# Altium schematic sheet 2-1 CPU.SchDoc("2-1 CPU")
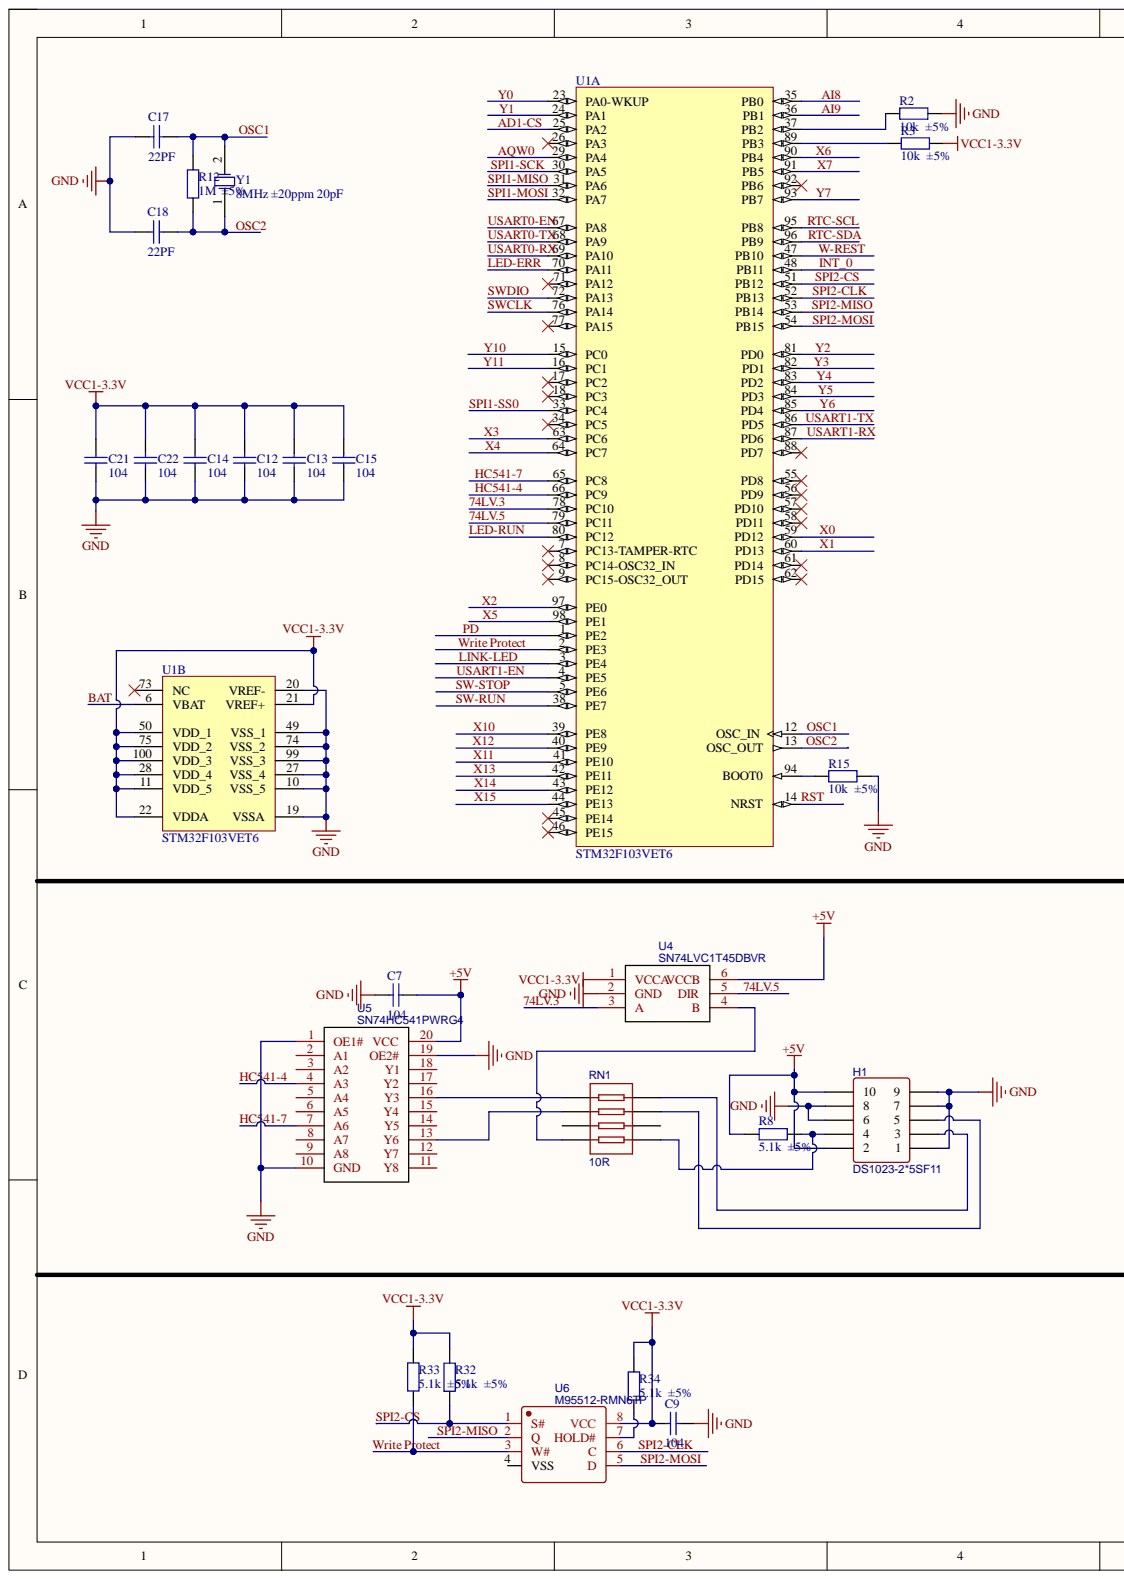
[diagram p0: 2-1 CPU.SchDoc, left]
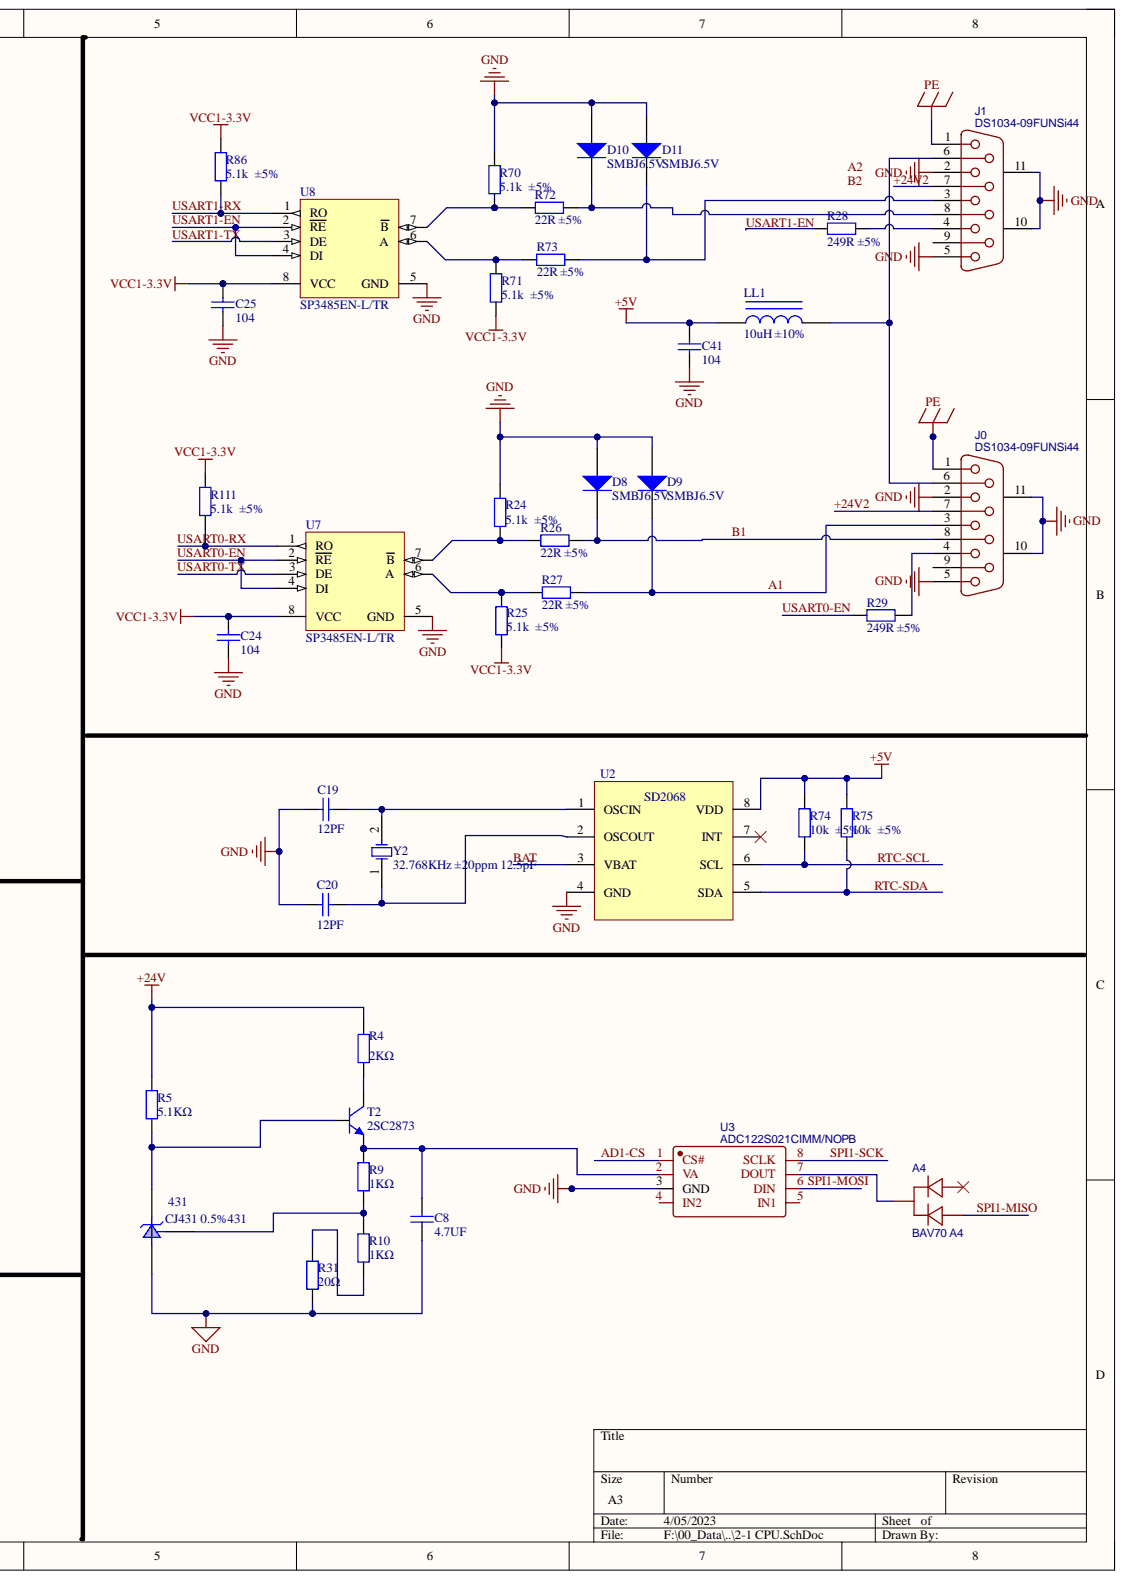
[diagram p1: 2-1 CPU.SchDoc, right]

## Parts
431  CJ431 0.5%431  [SOT-23(SOT-23-3)]
A4  BAV70 A4  [SOT-23-3_L2.9-W1.3-P1.90-LS2.4-BR]
C7  104  [0805_C]
C8  4.7UF  [0603_C]
C9  104  [0805_C]
C12  104  [0805_C]
C13  104  [0805_C]
C14  104  [0805_C]
C15  104  [0805_C]
C17  22PF  [0805_C]
C18  22PF  [0805_C]
C19  12PF  [0805_C]
C20  12PF  [0805_C]
C21  104  [0805_C]
C22  104  [0805_C]
C24  104  [0805_C]
C25  104  [0805_C]
C41  104  [0805_C]
D8  SMBJ6.5V  [SMC(DO-214AB)_S1]
D9  SMBJ6.5V  [SMC(DO-214AB)_S1]
D10  SMBJ6.5V  [SMC(DO-214AB)_S1]
D11  SMBJ6.5V  [SMC(DO-214AB)_S1]
H1  DS1023-2*5SF11  [HDR-TH_10P-P2.54-V-F-R2-C5-S2.54]
J0  DS1034-09FUNSi44  [DSUB-TH_2-5747706-0]
J1  DS1034-09FUNSi44  [DSUB-TH_2-5747706-0]
LL1  10uH ±10%  [0805_L]
R2  10k  ±5%  [0603_R]
R3  10k  ±5%  [0603_R]
R4  2KΩ  [0603_R]
R5  5.1KΩ  [0603_R]
R8  5.1k  ±5%  [0603_R]
R9  1KΩ  [0603_R]
R10  1KΩ  [0603_R]
R12  1M  ±5%  [0603_R]
R15  10k  ±5%  [0603_R]
R24  5.1k  ±5%  [0603_R]
R25  5.1k  ±5%  [0603_R]
R26  22R ±5%  [0603_R]
R27  22R ±5%  [0603_R]
R28  249R ±5%  [0603_R]
R29  249R ±5%  [0603_R]
R31  20Ω  [0603_R]
R32  5.1k  ±5%  [0603_R]
R33  5.1k  ±5%  [0603_R]
R34  5.1k  ±5%  [0603_R]
R70  5.1k  ±5%  [0603_R]
R71  5.1k  ±5%  [0603_R]
R72  22R ±5%  [0603_R]
R73  22R ±5%  [0603_R]
R74  10k  ±5%  [0603_R]
R75  10k  ±5%  [0603_R]
R86  5.1k  ±5%  [0603_R]
R111  5.1k  ±5%  [0603_R]
RN1  10R  [RES-ARRAY-SMD_0603-8P-L3.2-W1.6-BL]
T2  2SC2873  [SOT23-3N]
U1  STM32F103VET6  [LQFP-100_14x14x05P]  (parts A,B)
U2  SD2068
U3  ADC122S021CIMM/NOPB  [VSSOP-8_L3.0-W3.0-P0.65-LS5.0-BL]
U4  SN74LVC1T45DBVR  [SOT-23-6_L2.9-W1.6-P0.95-LS2.8-BR]
U5  SN74HC541PWRG4  [TSSOP-20_L6.5-W4.4-P0.65-LS6.4-BL]
U6  M95512-RMN6TP  [SOIC-8_L4.9-W3.9-P1.27-LS6.0-BL]
U7  SP3485EN-L/TR  [SOIC-8_150mil]
U8  SP3485EN-L/TR  [SOIC-8_150mil]
Y1  8MHz ±20ppm 20pF  [SMD-5032_2P]
Y2  32.768KHz ±20ppm 12.5pF  [SMD-3215_2P FC - 135]

## Nets
+5V: C7.1 C41.1 H1.2 H1.10 LL1.1 R8.1 R74.2 R75.2 U2.8(VDD) U4.6(VCCB) U5.20(VCC)
+24V: R4.1 R5.1
GND: 431.3 C7.2 C8.1 C9.2 C12.1 C13.1 C14.2 C15.1 C17.2 C18.2 C19.2 C20.2 C21.2 C22.2 C24.2 C25.2 C41.2 D8.1 D9.1 D10.1 D11.1 H1.1 H1.6 H1.7 H1.8 H1.9 J0.2 J0.5 J0.10 J0.11 J1.2 J1.5 J1.10 J1.11 R2.1 R15.1 R24.1 R31.2 R70.1 U1.10(VSS_5) U1.19(VSSA) U1.20(VREF-) U1.27(VSS_4) U1.49(VSS_1) U1.74(VSS_2) U1.99(VSS_3) U2.4(GND) U3.3(GND) U4.2(GND) U5.1(OE1#) U5.10(GND) U5.19(OE2#) U7.5(GND) U8.5(GND)
PE: J0.1 J1.1
VCC1-3.3V: C9.1 C12.2 C13.2 C14.1 C15.2 C21.1 C22.1 C24.1 C25.1 R3.1 R25.1 R32.1 R33.1 R34.2 R71.1 R86.1 R111.1 U1.11(VDD_5) U1.21(VREF+) U1.22(VDDA) U1.28(VDD_4) U1.50(VDD_1) U1.75(VDD_2) U1.100(VDD_3) U4.1(VCCA) U6.8(VCC) U7.8(VCC) U8.8(VCC)
74LV.3: U1.78(PC10) U4.3(A)
74LV.5: U1.79(PC11) U4.5(DIR)
+24V2: J0.7 J1.7
A1: D9.2 J0.3 R27.1
AD1-CS: U1.25(PA2) U3.1(CS#)
AI8: U1.35(PB0)
AI9: U1.36(PB1)
AQW0: U1.29(PA4)
B1: D8.2 J0.8 R26.1
BAT: U1.6(VBAT) U2.3(VBAT)
HC541-4: U1.66(PC9) U5.4(A3)
HC541-7: U1.65(PC8) U5.7(A6)
INT_0: U1.48(PB11)
LED-ERR: U1.70(PA11)
LED-RUN: U1.80(PC12)
LINK-LED: U1.3(PE4)
OSC1: C17.1 R12.2 U1.12(OSC_IN) Y1.2
OSC2: C18.1 R12.1 U1.13(OSC_OUT) Y1.1
PD: U1.1(PE2)
RST: U1.14(NRST)
RTC-SCL: R74.1 U1.95(PB8) U2.6(SCL)
RTC-SDA: R75.1 U1.96(PB9) U2.5(SDA)
SPI1-MISO: A4.1 U1.31(PA6)
SPI1-MOSI: U1.32(PA7) U3.6(DIN)
SPI1-SCK: U1.30(PA5) U3.8(SCLK)
SPI1-SS0: U1.33(PC4)
SPI2-CLK: U1.52(PB13) U6.6(C)
SPI2-CS: R32.2 U1.51(PB12) U6.1(S#)
SPI2-MISO: U1.53(PB14) U6.2(Q)
SPI2-MOSI: U1.54(PB15) U6.5(D)
SW-RUN: U1.38(PE7)
SW-STOP: U1.5(PE6)
SWCLK: U1.76(PA14)
SWDIO: U1.72(PA13)
USART0-EN: R29.2 U1.67(PA8) U7.2(RE) U7.4(DI)
USART0-RX: R111.2 U1.69(PA10) U7.1(RO)
USART0-TX: U1.68(PA9) U7.3(DE)
USART1-EN: R28.2 U1.4(PE5) U8.2(RE) U8.4(DI)
USART1-RX: R86.2 U1.87(PD6) U8.1(RO)
USART1-TX: U1.86(PD5) U8.3(DE)
W-REST: U1.47(PB10)
Write Protect: R33.2 U1.2(PE3) U6.3(W#)
X0: U1.59(PD12)
X1: U1.60(PD13)
X2: U1.97(PE0)
X3: U1.63(PC6)
X4: U1.64(PC7)
X5: U1.98(PE1)
X6: U1.90(PB4)
X7: U1.91(PB5)
X10: U1.39(PE8)
X11: U1.41(PE10)
X12: U1.40(PE9)
X13: U1.42(PE11)
X14: U1.43(PE12)
X15: U1.44(PE13)
Y0: U1.23(PA0-WKUP)
Y1: U1.24(PA1)
Y2: U1.81(PD0)
Y3: U1.82(PD1)
Y4: U1.83(PD2)
Y5: U1.84(PD3)
Y6: U1.85(PD4)
Y7: U1.93(PB7)
Y10: U1.15(PC0)
Y11: U1.16(PC1)
Net431_1: 431.1 R9.2 R10.1
Net431_2: 431.2 R5.2 T2.1
NetA4_3: A4.3 U3.7(DOUT)
NetC8_2: C8.2 R9.1 T2.2 U3.2(VA)
NetC19_1: C19.1 U2.1(OSCIN) Y2.2
NetC20_1: C20.1 U2.2(OSCOUT) Y2.1
NetD10_2: D10.2 J1.8 R72.1
NetD11_2: D11.2 J1.3 R73.1
NetH1_3: H1.3 RN1.4
NetH1_4: H1.4 R8.2 RN1.1
NetH1_5: H1.5 RN1.3
NetJ0_4: J0.4 R29.1
NetJ0_6: J0.6 J1.6 LL1.2
NetJ1_4: J1.4 R28.1
NetR2_2: R2.2 U1.37(PB2)
NetR3_2: R3.2 U1.89(PB3)
NetR4_2: R4.2 T2.3
NetR10_2: R10.2 R31.1
NetR15_2: R15.2 U1.94(BOOT0)
NetR24_2: R24.2 R26.2 U7.7(B)
NetR25_2: R25.2 R27.2 U7.6(A)
NetR34_1: R34.1 U6.7(HOLD#)
NetR70_2: R70.2 R72.2 U8.7(B)
NetR71_2: R71.2 R73.2 U8.6(A)
NetRN1_5: RN1.5 U5.16(Y3)
NetRN1_6: RN1.6 U5.13(Y6)
NetRN1_8: RN1.8 U4.4(B)
A2:
B2:

Not connected: A4 2; J0 9; J1 9; RN1 2 7; U1 7(PC13-TAMPER-RTC) 8(PC14-OSC32_IN) 9(PC15-OSC32_OUT) 17(PC2) 18(PC3) 26(PA3) 34(PC5) 45(PE14) 46(PE15) 55(PD8) 56(PD9) 57(PD10) 58(PD11) 61(PD14) 62(PD15) 71(PA12) 73(NC) 77(PA15) 88(PD7) 92(PB6); U2 7(INT); U3 4(IN2) 5(IN1); U5 2(A1) 3(A2) 5(A4) 6(A5) 8(A7) 9(A8) 11(Y8) 12(Y7) 14(Y5) 15(Y4) 17(Y2) 18(Y1); U6 4(VSS)

## Library data of the parts
431 = CJ431 0.5%431: 电压基准; Component Kind: Standard; ComponentLink1Description: 供应商链接; ComponentLink1URL: http://www.szlcsc.com/product/details_3500.html; Library Name: 02-Miscellaneous_Devices_ZSF_Library.IntLib; Package: SOT-23; Supplier: LC; SuppliersPartNumber: C3113
A4 = BAV70 A4: BOM_Manufacturer: DOWO; Contributor: LCSC; BOM_JLCPCB Part Class: Extended Part; BOM_Manufacturer Part: BAV70 A4; BOM_Supplier: LCSC; BOM_Supplier Part: C669466; Component Kind: Standard; spicePre: D; spiceSymbolName: BAV70 A4
C7 C9 C12-C15 C21 C22 C24 C25 C41 = Cap_0805: 0805贴片电容; Component Kind: Standard; Library Name: 02-Miscellaneous_Devices_ZSF_Library.IntLib; Package: 0805; Value: 104; 常见贴片电容尺寸图: https://wenku.baidu.com/view/21d5206100f69e3143323968011ca300a6c3f6b2.html
C8 = Cap_0603: 0603贴片电容; Value: 104; Component Kind: Standard; Library Name: 02-Miscellaneous_Devices_ZSF_Library.IntLib; Package: 0805; 常见贴片电容尺寸图: https://wenku.baidu.com/view/21d5206100f69e3143323968011ca300a6c3f6b2.html
C17-C20 = Cap_0805: 0805贴片电容; Value: 104; Component Kind: Standard; Library Name: 02-Miscellaneous_Devices_ZSF_Library.IntLib; Package: 0805; 常见贴片电容尺寸图: https://wenku.baidu.com/view/21d5206100f69e3143323968011ca300a6c3f6b2.html
D8-D11 = SS36-E3/57T_SMC(DO-214AB): 二极管; Component Kind: Standard; ComponentLink1Description: 供应商链接; ComponentLink1URL: http://www.szlcsc.com/product/details_36698.html; Library Name: 02-Miscellaneous_Devices_ZSF_Library.IntLib; Package: SMC(DO-214AB); Supplier: LC; SuppliersPartNumber: C35722
H1 = DS1023-2*5SF11: BOM_Manufacturer: CONNFLY; Contributor: LCSC; BOM_JLCPCB Part Class: Extended Part; BOM_Manufacturer Part: DS1023-2*5SF11; BOM_Supplier: LCSC; BOM_Supplier Part: C92270; Component Kind: Standard; spicePre: H; spiceSymbolName: DS1023-2*5SF11
J0 J1 = DS1034-09FUNSi44: BOM_Manufacturer: CONNFLY; Contributor: LCSC; BOM_JLCPCB Part Class: Extended Part; BOM_Manufacturer Part: DS1034-09FUNSi44; BOM_Supplier: LCSC; BOM_Supplier Part: C77831; Component Kind: Standard; spicePre: J; spiceSymbolName: DS1034-09FUNSi44
LL1 = 0805 10uH 10%: 贴片电感; Component Kind: Standard; ComponentLink1Description: 供应商链接; ComponentLink1URL: http://www.szlcsc.com/product/details_1398.html; Library Name: 02-Miscellaneous_Devices_ZSF_Library.IntLib; Package: 0805; Supplier: LC; SuppliersPartNumber: C1046
R2-R5 R8-R10 R12 R15 R24-R29 R31-R34 R70-R75 R86 R111 = Res_0603: 贴片电阻; Component Kind: Standard; ComponentLink1Description: 供应商链接; ComponentLink1URL: http://www.szlcsc.com/product/details_21903.html; Library Name: 02-Miscellaneous_Devices_ZSF_Library.IntLib; Package: 0603; Supplier: LC; SuppliersPartNumber: C21189
RN1 = 4.7K: BOM_Manufacturer: Ever Ohms Tech; BOM_Manufacturer Part: CRA034RF4K7P05; Contributor: LCSC; nameAlias: Resistance (Ohms); BOM_JLCPCB Part Class: Extended Part; BOM_Supplier: LCSC; BOM_Supplier Part: C149871; Component Kind: Standard; spicePre: R; spiceSymbolName: CRA034RF4K7P05
T2 = 9013-SMD: 低频放大-NPN型; 属性: 50V 0.5A 0.625W; 版权归属: 源创客; BOM名称: 低频放大-NPN型; Component Kind: Standard; Library Name: 三极管.SchLib; 学习资源: www.ooocke.com
U1 = STM32F103VET6: ARM; Component Kind: Standard; ComponentLink1Description: 供应商链接; ComponentLink1URL: http://www.szlcsc.com/product/details_8800.html; Library Name: 02-Miscellaneous_Devices_ZSF_Library.IntLib; Package: LQFP-100_14x14x05P; Supplier: LC; SuppliersPartNumber: C8308
U3 = ADC122S021CIMM/NOPB: BOM_Manufacturer: Texas Instruments; Contributor: LCSC; BOM_JLCPCB Part Class: Extended Part; BOM_Manufacturer Part: ADC122S021CIMM/NOPB; BOM_Supplier: LCSC; BOM_Supplier Part: C206499; Component Kind: Standard; spicePre: U; spiceSymbolName: ADC122S021CIMM/NOPB
U4 = SN74LVC1T45DBVR: BOM_Manufacturer: TI; BOM_JLCPCB Part Class: Extended Part; BOM_Manufacturer Part: SN74LVC1T45DBVR; BOM_Supplier: LCSC; BOM_Supplier Part: C7843; Component Kind: Standard; spicePre: U; spiceSymbolName: SN74LVC1T45DBVR
U5 = SN74HC541PWRG4: BOM_Manufacturer: TI; BOM_JLCPCB Part Class: Extended Part; BOM_Manufacturer Part: SN74HC541PWRG4; BOM_Supplier: LCSC; BOM_Supplier Part: C6758; Component Kind: Standard; spicePre: U; spiceSymbolName: SN74HC541PWRG4
U6 = M95512-RMN6TP: BOM_Manufacturer: STMicroelectronics; Contributor: LCSC; BOM_JLCPCB Part Class: Extended Part; BOM_Manufacturer Part: M95512-RMN6TP; BOM_Supplier: LCSC; BOM_Supplier Part: C133775; Component Kind: Standard; spicePre: U; spiceSymbolName: M95512-RMN6TP
U7 U8 = SP3485EN-L/TR: RS-485/RS-422; Component Kind: Standard; ComponentLink1Description: 供应商链接; ComponentLink1URL: http://www.szlcsc.com/product/details_9482.html; Library Name: 02-Miscellaneous_Devices_ZSF_Library.IntLib; Package: SOIC-8; Supplier: LC; SuppliersPartNumber: C8963
Y1 = SMD-5032_2P 8MHz 20ppm 20pF: 贴片晶振; Component Kind: Standard; ComponentLink1Description: 供应商链接; ComponentLink1URL: http://www.szlcsc.com/product/details_19261.html; Library Name: 02-Miscellaneous_Devices_ZSF_Library.IntLib; Package: SMD-5032_2P; Supplier: LC; SuppliersPartNumber: C18560
Y2 = SMD-3215_2P 32.768KHz 20ppm 12.5pF: 贴片晶振; Component Kind: Standard; ComponentLink1Description: 供应商链接; ComponentLink1URL: http://www.szlcsc.com/product/details_33310.html; Library Name: 02-Miscellaneous_Devices_ZSF_Library.IntLib; Package: SMD-3215_2P; Supplier: LC; SuppliersPartNumber: C32346
U2 = SD2068: Component Kind: Standard; Library Name: SD2068.SchLib
